# Altium schematic sheet SSS Connections.SchDoc
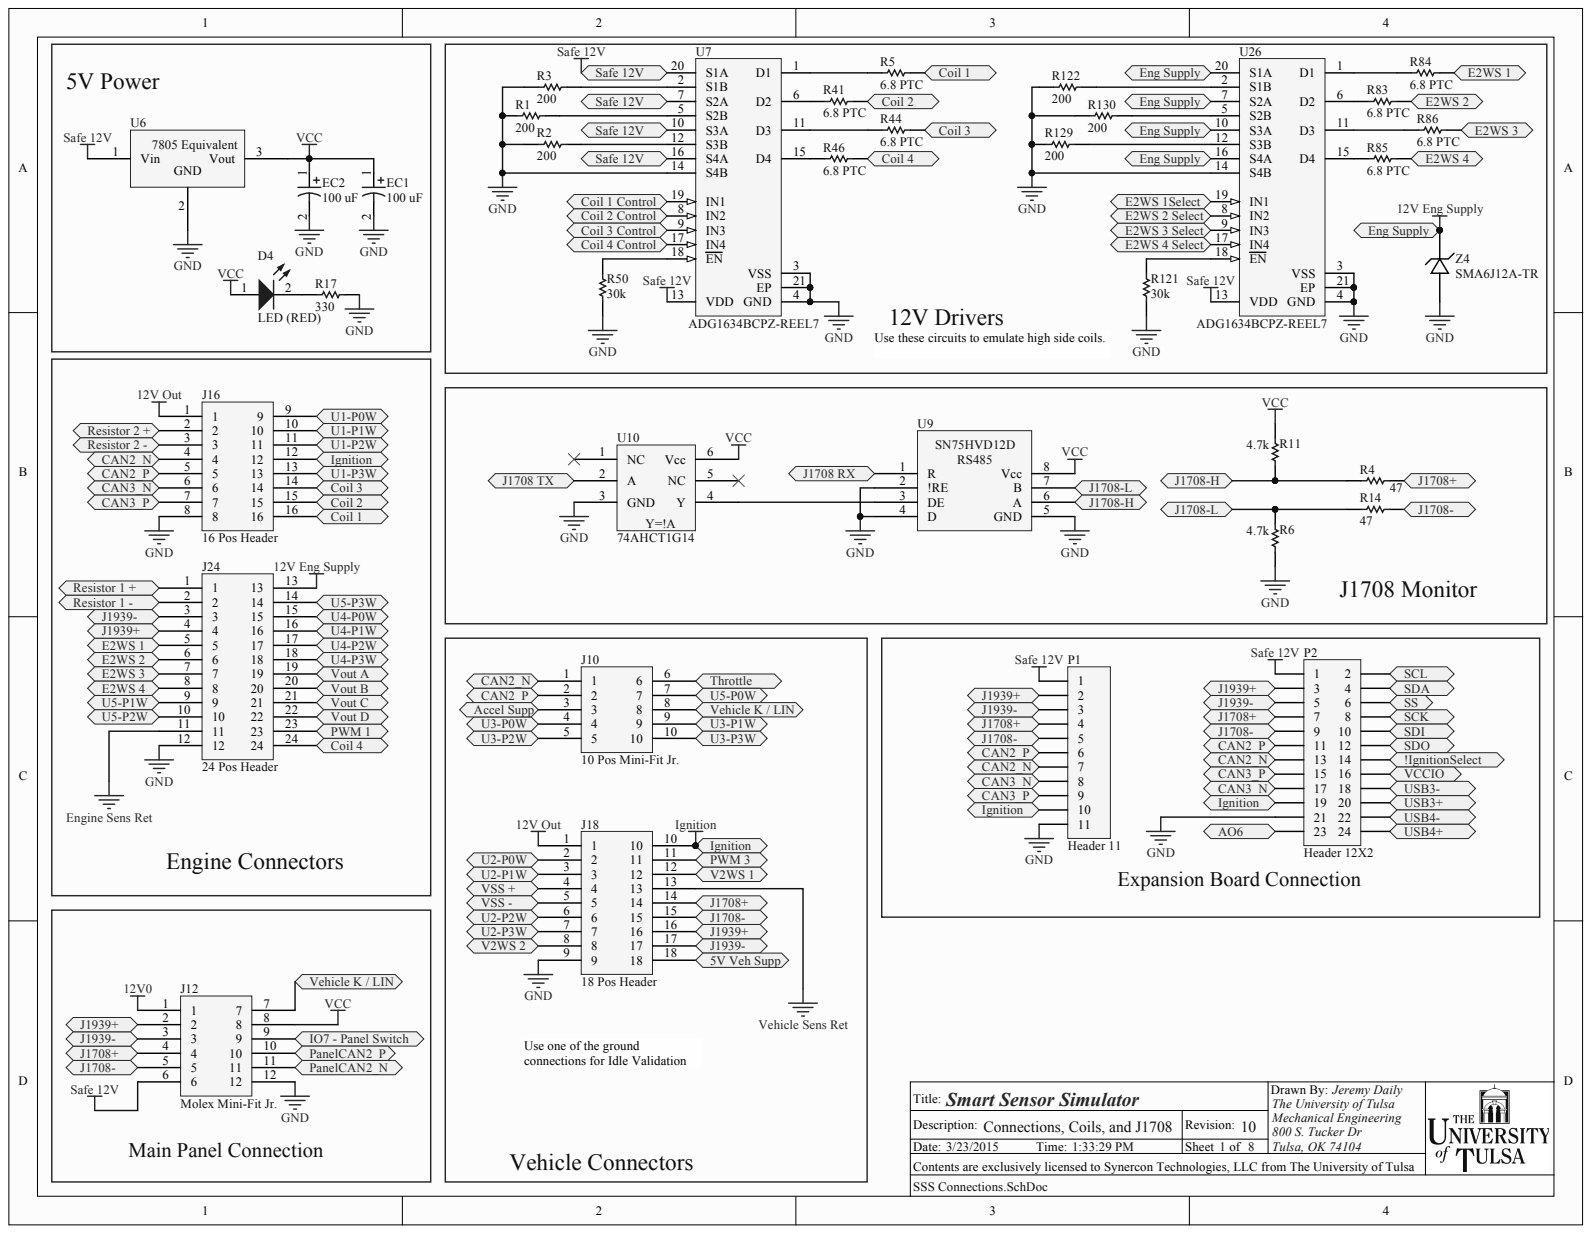
[diagram p0: SSS Connections.SchDoc]

## Parts
D4  LED (RED)  [1206 Polarized]
EC1  100 uF  [Elec. Cap - D]
EC2  100 uF  [Elec. Cap - D]
J10  10 Pos Mini-Fit Jr.  [10 pos Mini-Fit Jr. R/A Header]
J12  Molex Mini-Fit Jr.  Manufacturer: Molex  [12 pos Mini-Fit Jr. R/A Header]
J16  16 Pos Header  [16 pos Mini-Fit Jr. R/A Header]
J18  18 Pos Header  [18 pos Mini-Fit Jr. R/A Header]
J24  24 Pos Header  [24 pos Mini-Fit Jr. R/A Header]
P1  Header 11  [HDR1X11]
P2  Header 12X2  [HDR2X12]
R1  200  [0805]
R2  200  [0805]
R3  200  [0805]
R4  47  [0805]
R5  6.8 PTC  [0805]
R6  4.7k  [0805]
R11  4.7k  [0805]
R14  47  [0805]
R17  330  [0603]
R41  6.8 PTC  [0805]
R44  6.8 PTC  [0805]
R46  6.8 PTC  [0805]
R50  30k  [0805]
R83  6.8 PTC  [0805]
R84  6.8 PTC  [0805]
R85  6.8 PTC  [0805]
R86  6.8 PTC  [0805]
R121  30k  [0805]
R122  200  [0805]
R129  200  [0805]
R130  200  [0805]
U6  7805 Equivalent  [78xx]
U7  ADG1634BCPZ-REEL7  [ADI-CP-20-4_N]
U9  SN75HVD12D (3.3v RS485)  [SOIC-8]  (value not drawn)
U10  74AHCT1G14  [SOT-353]
U26  ADG1634BCPZ-REEL7  [ADI-CP-20-4_N]
Z4  SMA6J12A-TR  [SMA with A and K]

## Ports
5V VEH SUPP, !IGNITIONSELECT, ACCEL SUPP, AO6, CAN2_N, CAN2_P, CAN3_N, CAN3_P, COIL 1, COIL 1 CONTROL, COIL 2, COIL 2 CONTROL, COIL 3, COIL 3 CONTROL, COIL 4, COIL 4 CONTROL, E2WS 1, E2WS 1SELECT, E2WS 2, E2WS 2 SELECT, E2WS 3, E2WS 3 SELECT, E2WS 4, E2WS 4 SELECT, ENG SUPPLY, IGNITION, IO7 - PANEL SWITCH, J1708 RX, J1708 TX, J1708+, J1708-, J1708-H, J1708-L, J1939+, J1939-, PANELCAN2_N, PANELCAN2_P, PWM 1, PWM 3, RESISTOR 1 +, RESISTOR 1 -, RESISTOR 2 +, RESISTOR 2 -, SAFE 12V, SCK, SCL, SDA, SDI, SDO, SS, THROTTLE, U1-P0W, U1-P1W, U1-P2W, U1-P3W, U2-P0W, U2-P1W, U2-P2W, U2-P3W, U3-P0W, U3-P1W, U3-P2W, U3-P3W, U4-P0W, U4-P1W, U4-P2W, U4-P3W, U5-P0W, U5-P1W, U5-P2W, U5-P3W, USB3+, USB3-, USB4+, USB4-, V2WS 1, V2WS 2, VCCIO, VEHICLE K / LIN, VOUT A, VOUT B, VOUT C, VOUT D, VSS +, VSS -

## Nets
12V0: J12.1
12V ENG SUPPLY: J24.13 U26.7(S2A) U26.10(S3A) U26.16(S4A) U26.20(S1A) Z4.2 ; port ENG SUPPLY
12V OUT: J16.1 J18.1
ENGINE SENS RET: J24.11
GND: EC1.2 EC2.2 J12.12 J16.8 J18.9 J24.12 P1.11 P2.21 R1.1 R2.1 R3.1 R6.2 R17.2 R50.2 R121.2 R122.2 R129.2 R130.2 U6.2 U7.3(VSS) U7.4(GND) U7.14(S4B) U7.21(EP) U9.2(!RE) U9.4(D) U9.5(GND) U10.3(GND) U26.3(VSS) U26.4(GND) U26.14(S4B) U26.21(EP) Z4.1
IGNITION: J16.12 J18.10 P1.10 P2.19 ; port IGNITION
SAFE 12V: J12.6 P1.1 P2.1 U6.1 U7.7(S2A) U7.10(S3A) U7.13(VDD) U7.16(S4A) U7.20(S1A) U26.13(VDD) ; port SAFE 12V
VCC: D4.1 EC1.1 EC2.1 J12.8 R11.1 U6.3 U9.8(Vcc) U10.6(Vcc)
VEHICLE SENS RET: J18.13
AO6: P2.23 ; port AO6
CAN2_N: J10.1 J16.4 P1.7 P2.13 ; port CAN2_N
CAN2_P: J10.2 J16.5 P1.6 P2.11 ; port CAN2_P
CAN3_N: J16.6 P1.8 P2.17 ; port CAN3_N
CAN3_P: J16.7 P1.9 P2.15 ; port CAN3_P
E2WS 1: J24.5 R84.2 ; port E2WS 1
E2WS 2: J24.6 R83.1 ; port E2WS 2
E2WS 3: J24.7 R86.2 ; port E2WS 3
E2WS 4: J24.8 R85.1 ; port E2WS 4
J1708 RX: U9.1(R) ; port J1708 RX
J1708 TX: U10.2(A) ; port J1708 TX
J1708-H: R4.1 R11.2 U9.6(A) ; port J1708-H
J1708-L: R6.1 R14.1 U9.7(B) ; port J1708-L
PWM 1: J24.23 ; port PWM 1
PWM 3: J18.11 ; port PWM 3
SCK: P2.8 ; port SCK
SCL: P2.2 ; port SCL
SDA: P2.4 ; port SDA
SDI: P2.10 ; port SDI
SDO: P2.12 ; port SDO
SS: P2.6 ; port SS
U1-P0W: J16.9 ; port U1-P0W
U1-P1W: J16.10 ; port U1-P1W
U1-P2W: J16.11 ; port U1-P2W
U1-P3W: J16.13 ; port U1-P3W
U2-P0W: J18.2 ; port U2-P0W
U2-P1W: J18.3 ; port U2-P1W
U2-P2W: J18.6 ; port U2-P2W
U2-P3W: J18.7 ; port U2-P3W
U3-P0W: J10.4 ; port U3-P0W
U3-P1W: J10.9 ; port U3-P1W
U3-P2W: J10.5 ; port U3-P2W
U3-P3W: J10.10 ; port U3-P3W
U4-P0W: J24.15 ; port U4-P0W
U4-P1W: J24.16 ; port U4-P1W
U4-P2W: J24.17 ; port U4-P2W
U4-P3W: J24.18 ; port U4-P3W
U5-P0W: J10.7 ; port U5-P0W
U5-P1W: J24.9 ; port U5-P1W
U5-P2W: J24.10 ; port U5-P2W
U5-P3W: J24.14 ; port U5-P3W
V2WS 1: J18.12 ; port V2WS 1
V2WS 2: J18.8 ; port V2WS 2
VCCIO: P2.16 ; port VCCIO
NetD4_2: D4.2 R17.1
NetJ10_3: J10.3 ; port ACCEL SUPP
NetJ10_6: J10.6 ; port THROTTLE
NetJ10_8: J10.8 J12.7 ; port VEHICLE K / LIN
NetJ12_2: J12.2 J18.16 J24.4 P1.2 P2.3
NetJ12_3: J12.3 J18.17 J24.3 P1.3 P2.5
NetJ12_4: J12.4 J18.14 P1.4 P2.7 R4.2
NetJ12_5: J12.5 J18.15 P1.5 P2.9 R14.2
NetJ12_9: J12.9 ; port IO7 - PANEL SWITCH
NetJ12_10: J12.10 ; port PANELCAN2_P
NetJ12_11: J12.11 ; port PANELCAN2_N
NetJ16_2: J16.2
NetJ16_3: J16.3
NetJ16_14: J16.14 R44.1 ; port COIL 3
NetJ16_15: J16.15 R41.1 ; port COIL 2
NetJ16_16: J16.16 R5.1 ; port COIL 1
NetJ18_4: J18.4
NetJ18_5: J18.5
NetJ18_18: J18.18 ; port 5V VEH SUPP
NetJ24_1: J24.1
NetJ24_2: J24.2
NetJ24_19: J24.19 ; port VOUT A
NetJ24_20: J24.20 ; port VOUT B
NetJ24_21: J24.21 ; port VOUT C
NetJ24_22: J24.22 ; port VOUT D
NetJ24_24: J24.24 R46.1 ; port COIL 4
NetP2_14: P2.14 ; port !IGNITIONSELECT
NetP2_18: P2.18
NetP2_20: P2.20
NetP2_22: P2.22
NetP2_24: P2.24
NetR1_2: R1.2 U7.5(S2B)
NetR2_2: R2.2 U7.12(S3B)
NetR3_2: R3.2 U7.2(S1B)
NetR5_2: R5.2 U7.1(D1)
NetR41_2: R41.2 U7.6(D2)
NetR44_2: R44.2 U7.11(D3)
NetR46_2: R46.2 U7.15(D4)
NetR50_1: R50.1 U7.18(EN)
NetR83_2: R83.2 U26.6(D2)
NetR84_1: R84.1 U26.1(D1)
NetR85_2: R85.2 U26.15(D4)
NetR86_1: R86.1 U26.11(D3)
NetR121_1: R121.1 U26.18(EN)
NetR122_1: R122.1 U26.2(S1B)
NetR129_1: R129.1 U26.12(S3B)
NetR130_1: R130.1 U26.5(S2B)
NetU7_8: U7.8(IN2) ; port COIL 2 CONTROL
NetU7_9: U7.9(IN3) ; port COIL 3 CONTROL
NetU7_17: U7.17(IN4) ; port COIL 4 CONTROL
NetU7_19: U7.19(IN1) ; port COIL 1 CONTROL
NetU9_3: U9.3(DE) U10.4(Y)
NetU26_8: U26.8(IN2) ; port E2WS 2 SELECT
NetU26_9: U26.9(IN3) ; port E2WS 3 SELECT
NetU26_17: U26.17(IN4) ; port E2WS 4 SELECT
NetU26_19: U26.19(IN1) ; port E2WS 1SELECT
N00006:

Not connected: U10 1(NC) 5(NC)

## Library data of the parts
D4 = SMT LED: LED 3.2X1.6MM 635NM RED CLR SMD; Manufacturer: Kingbright; PartNumber: APTD3216SURCK; Component Kind: Standard; Library Name: SSS Schematic Library.SchLib; Supplier 1: Digi-Key; Supplier Part Number 1: 754-1159-1-ND
EC1 EC2 = SMD Elec: CAP ALUM 100UF 16V 20% SMD; Manufacturer: Panasonic; PartNumber: EEE-HC1C101XP; Component Kind: Standard; Library Name: SSS Schematic Library.SchLib; Supplier 1: Digi-Key; Supplier Part Number 1: PCE3750CT-ND
J10 = 10 Pos Header: CONN HEADER 10POS 4.2MM R/A TIN; Manufacturer: Molex; Component Kind: Standard; Library Name: Mini Fit Jr.SchLib; PartNumber: 39-30-1100; Supplier 1: Digi-Key; Supplier Part Number 1: WM1355-ND
J12 = 12 Pos Header: CONN HEADER 12POS 4.2MM R/A TIN; Component Kind: Standard; Library Name: Mini Fit Jr.SchLib; PartNumber: 39-30-1120; Supplier 1: Digi-Key; Supplier Part Number 1: WM1356-ND
J16 = 16 Pos Header: CONN HEADER 16POS 4.2MM R/A TIN; Manufacturer: Molex; Component Kind: Standard; Library Name: Mini Fit Jr.SchLib; PartNumber: 39-30-1140; Supplier 1: Digi-Key; Supplier Part Number 1: WM1358-ND
J18 = 18 Pos Header: CONN HEADER 18POS RT ANG TIN; Manufacturer: Molex; Component Kind: Standard; Library Name: Mini Fit Jr.SchLib; PartNumber: 39-30-1180; Supplier 1: Digi-Key; Supplier Part Number 1: WM1359-ND
J24 = 24 Pos Header: CONN HEADER 24POS 4.2MM R/A TIN; Manufacturer: Molex; Component Kind: Standard; Library Name: Mini Fit Jr.SchLib; PartNumber: 39-30-1240; Supplier 1: Digi-Key; Supplier Part Number 1: WM1362-ND
P1 = Header 11: Header, 11-Pin Do Not Populate; Component Kind: Standard; Library Name: Miscellaneous Connectors.IntLib; Signal Integrity: Connector
P2 = Header 12X2: Header, 12-Pin, Dual row; Manufacturer: 3M; PartNumber: 929836-01-12-RK; Component Kind: Standard; Library Name: Miscellaneous Connectors.IntLib; Signal Integrity: Connector; Supplier 1: Digi-Key; Supplier Part Number 1: 929836E-01-12-ND
R1-R3 R122 R129 R130 = Chip Resistor: RES SMD 200 OHM 1% 1/2W 0805; Manufacturer: Panasonic Electronic Components; PartNumber: ERJ-P06F2000V; Component Kind: Standard; Library Name: SSS Schematic Library.SchLib; Supplier 1: Digi-Key; Supplier Part Number 1: P16064CT-ND
R4 R14 = Chip Resistor: RES SMD 47 OHM 5% 0.4W 0805; Manufacturer: Rohm Semiconductor; PartNumber: ESR10EZPJ470; Component Kind: Standard; Library Name: SSS Schematic Library.SchLib; Supplier 1: Digi-Key; Supplier Part Number 1: RHM47KCT-ND
R5 R41 R44 R46 R83-R86 = SMD Resistor: THERMISTOR PTC 6.8 OHM SMD; Manufacturer: Murata Electronics North America; PartNumber: PRG21BC6R8MM1RA; Component Kind: Standard; Library Name: SSS Schematic Library.SchLib; Supplier 1: Digi-Key; Supplier Part Number 1: 490-4673-1-ND
R6 R11 = Chip Resistor: RES SMD 4.7K OHM 1% 1/8W 0805; Manufacturer: Panasonic Electronic Components; PartNumber: ERJ-6ENF4701V; Component Kind: Standard; Library Name: SSS Schematic Library.SchLib; Supplier 1: Digi-Key; Supplier Part Number 1: P4.70KCCT-ND
R17 = Chip Resistor: RES SMD 330 OHM 1% 1/10W 0603; Manufacturer: Panasonic Electronic Components; PartNumber: ERJ-3EKF3300V; Component Kind: Standard; Library Name: SSS Schematic Library.SchLib; Supplier 1: Digi-Key; Supplier Part Number 1: P330HCT-ND
R50 R121 = Chip Resistor: RES SMD 30K OHM 5% 1/8W 0805; Manufacturer: Samsung Electro-Mechanics America, Inc; PartNumber: RC2012J303CS; Component Kind: Standard; Library Name: SSS Schematic Library.SchLib; Supplier 1: Digi-Key; Supplier Part Number 1: 1276-5563-1-ND
U6 = 7805: REGULATOR SW SYNC 5V 2A SIP; Manufacturer: Murata Power Solutions Inc; PartNumber: 78SR-5/2-C; Component Kind: Standard; Library Name: SSS Schematic Library.SchLib; Supplier 1: Digi-Key; Supplier Part Number 1: 811-1119-ND
U7 U26 = ADG1634BCPZ-REEL7: IC SWITCH QUAD SPDT 20LFCSP; Manufacturer: Analog Devices; Component Kind: Standard; Library Name: Analog Devices Switches and Multiplexers Analog Switches.IntLib; PartNumber: ADG1634BCPZ-REEL7; Supplier 1: Digi-Key; Supplier Part Number 1: ADG1634BCPZ-REEL7CT-ND
U9 = SN75HVD12D (3.3v RS485): IC RS485 TXRX 3/5V 8-SOIC; Manufacturer: Texas Instruments; PartNumber: SN75HVD08DR; Component Kind: Standard; Library Name: SSS Schematic Library.SchLib; Supplier 1: Digi-Key; Supplier Part Number 1: 296-37893-1-ND
U10 = 74AHCT1G14 Inverter: IC SCHMIT-TRIGG INV GATE SOT353; Manufacturer: Diodes Incorporated; PartNumber: 74AHCT1G14SE-7; Component Kind: Standard; Library Name: SSS Schematic Library.SchLib; Supplier 1: Digi-Key; Supplier Part Number 1: 74AHCT1G14SE-7DICT-ND
Z4 = Zener: TVS DIODE 13VWM 21.5VC SMA; Manufacturer: Micro Commercial Co; PartNumber: SMA6J13CA-TP; Component Kind: Standard; Library Name: SSS Schematic Library.SchLib; Supplier 1: Digi-Key; Supplier Part Number 1: SMA6J13CA-TPMSCT-ND
